# Altium schematic sheet Launchpad.~(23).SchDoc(Launchpad.~(23))
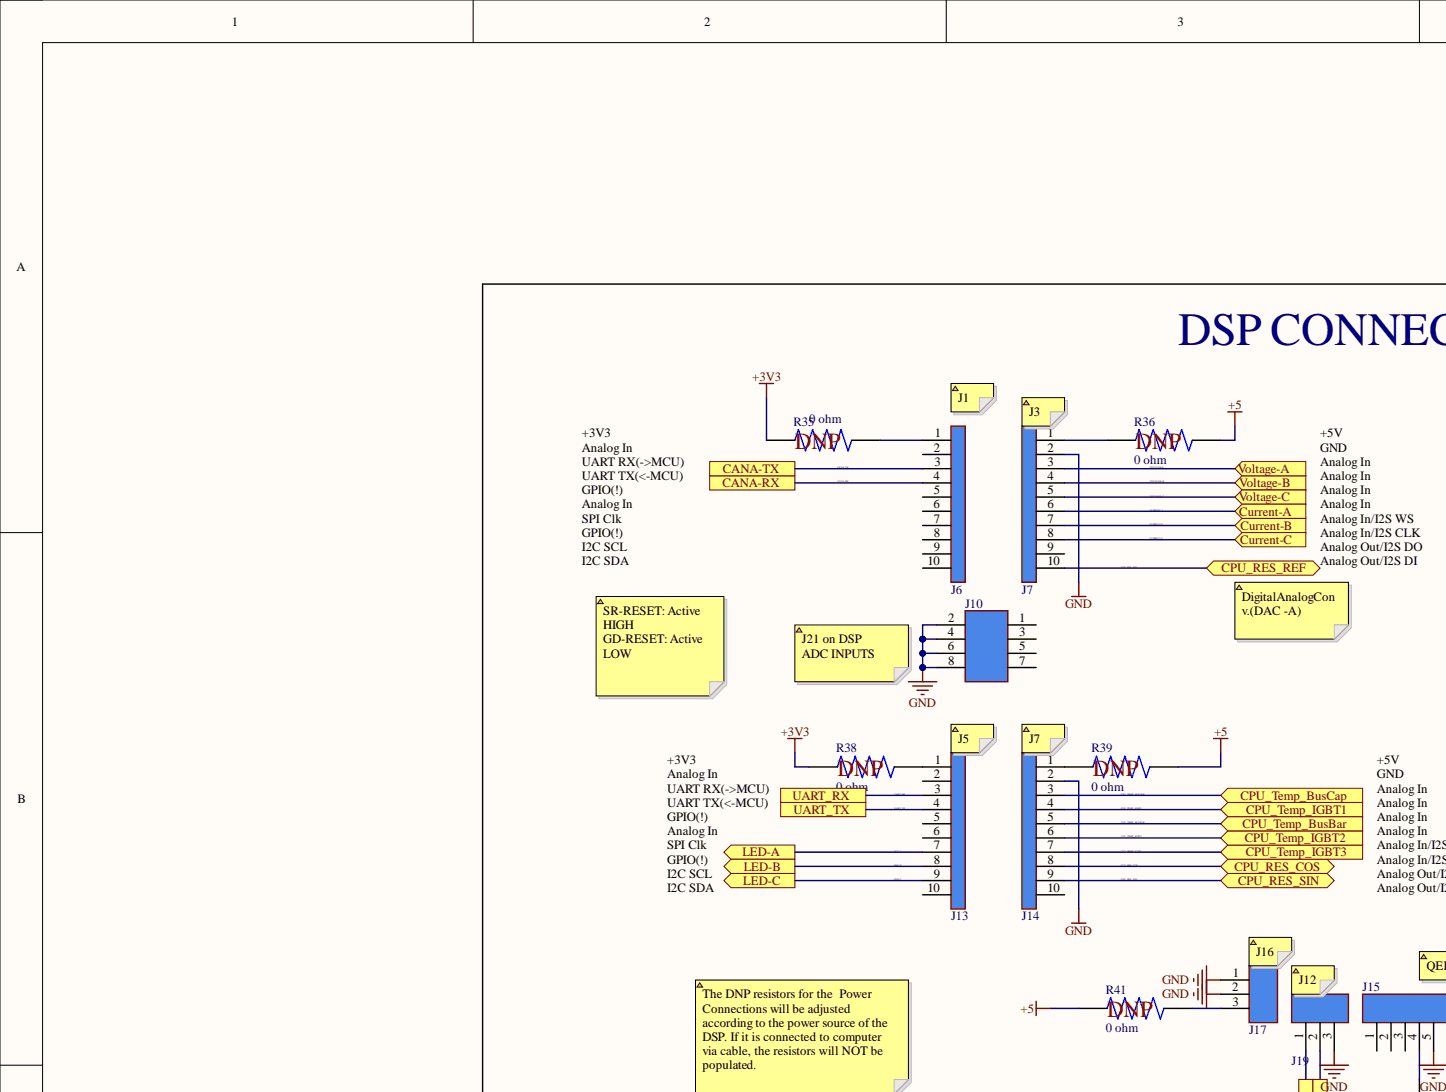
[diagram p0: Launchpad.~, top left]
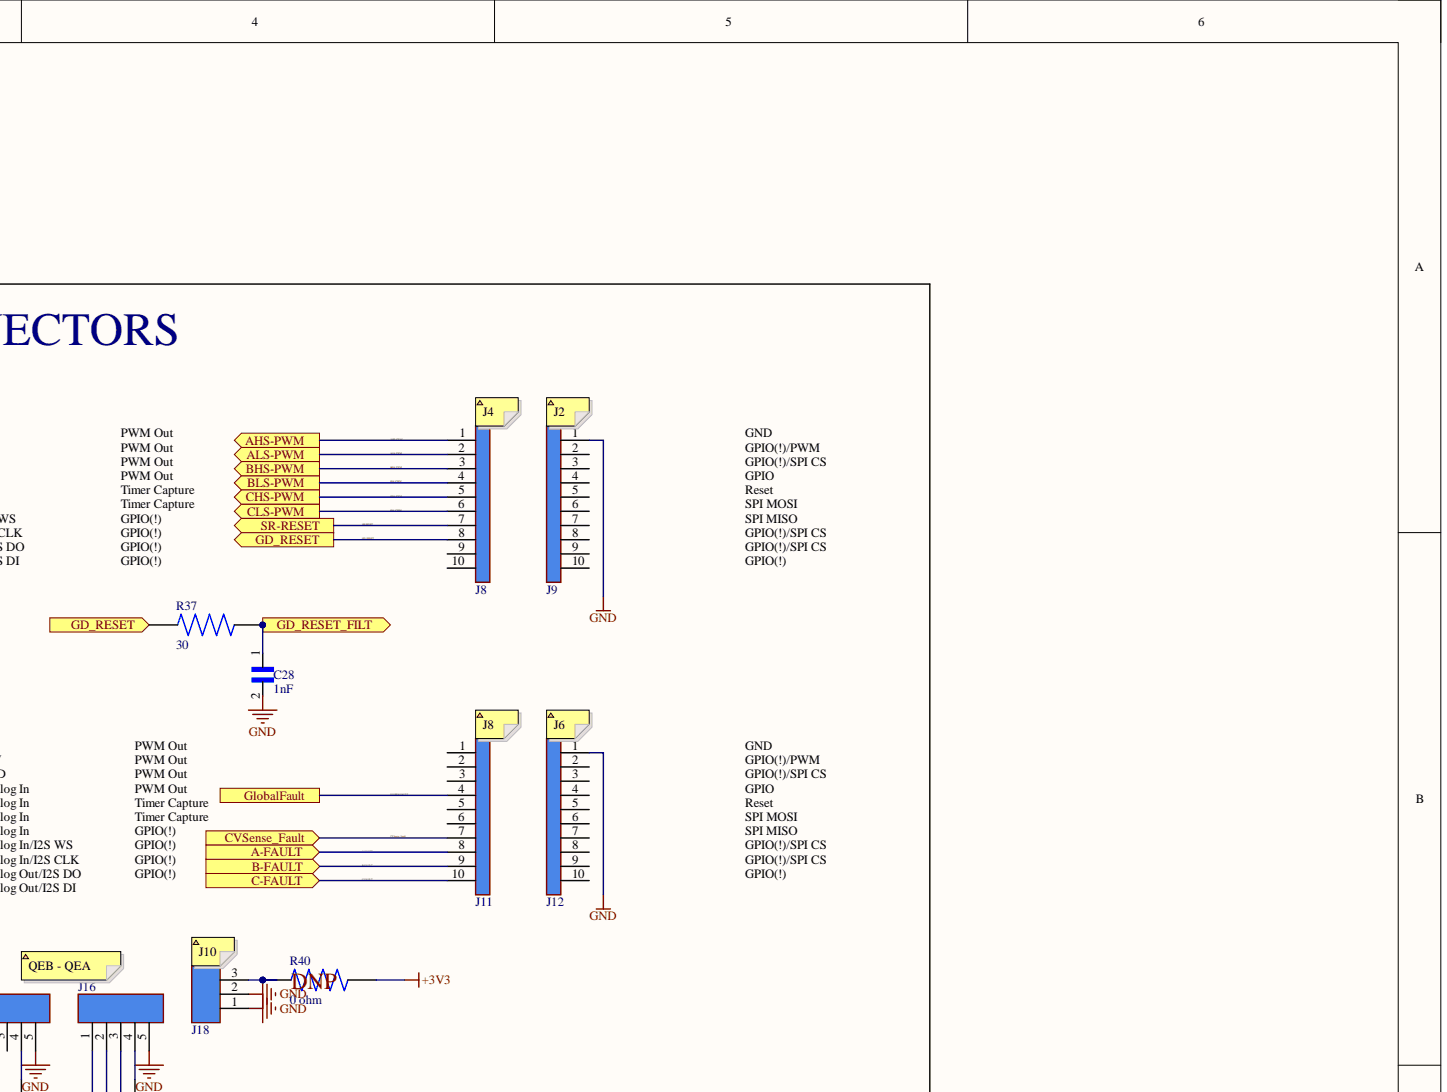
[diagram p1: Launchpad.~, top right]
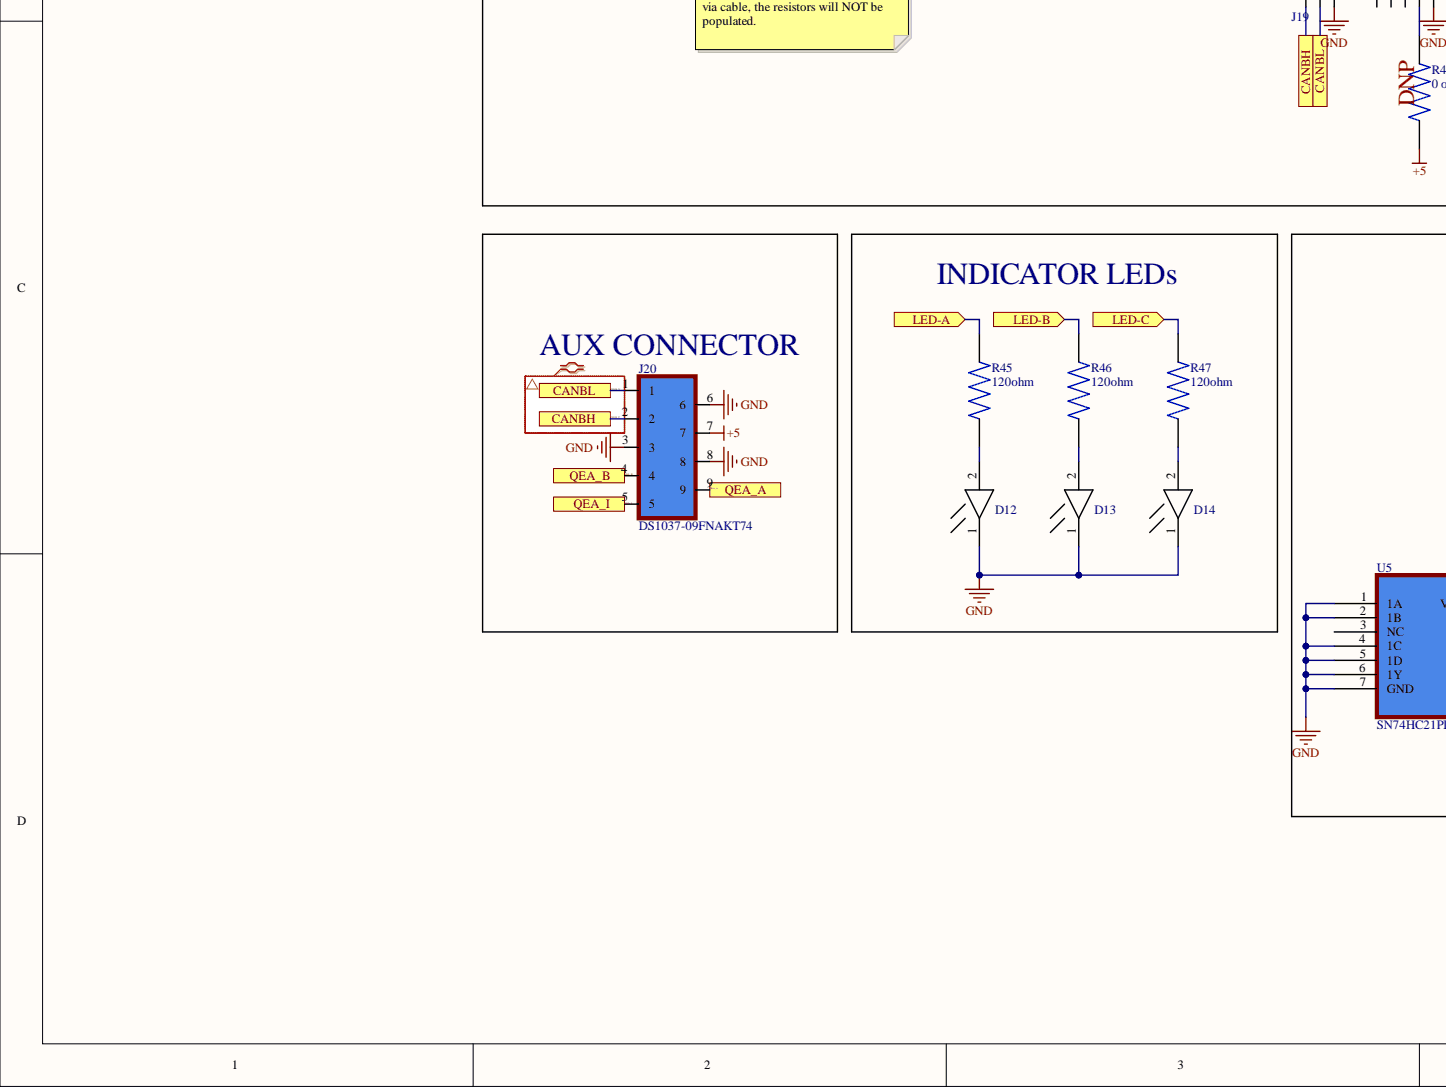
[diagram p2: Launchpad.~, bottom left]
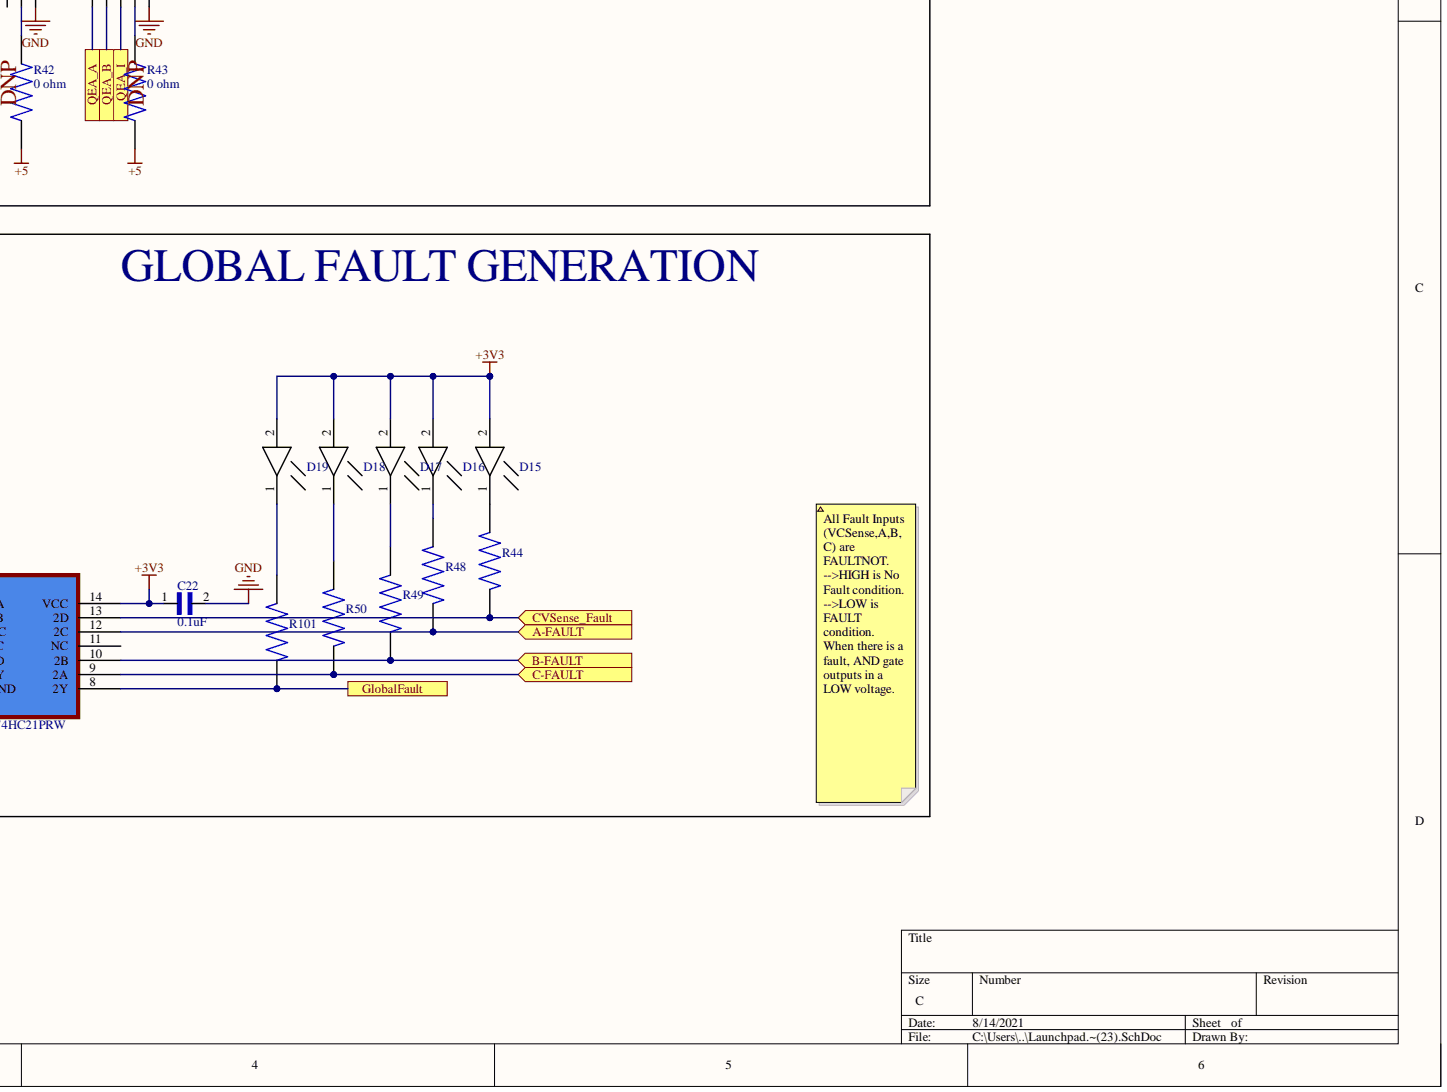
[diagram p3: Launchpad.~, bottom right]

## Parts
C22  0.1uF  [CAP 0805(2012 metric)]
C28  1nF  [CAP 0805(2012 metric)]
D12  LED 17-21/Y2C-AN1P2/3T  [17-21/Y2C-AN1P2/3T]  (value not drawn)
D13  LED 17-21/Y2C-AN1P2/3T  [17-21/Y2C-AN1P2/3T]  (value not drawn)
D14  LED 17-21/Y2C-AN1P2/3T  [17-21/Y2C-AN1P2/3T]  (value not drawn)
D15  LED 17-21/Y2C-AN1P2/3T  [17-21/Y2C-AN1P2/3T]  (value not drawn)
D16  LED 17-21/Y2C-AN1P2/3T  [17-21/Y2C-AN1P2/3T]  (value not drawn)
D17  LED 17-21/Y2C-AN1P2/3T  [17-21/Y2C-AN1P2/3T]  (value not drawn)
D18  LED 17-21/Y2C-AN1P2/3T  [17-21/Y2C-AN1P2/3T]  (value not drawn)
D19  LED 17-21/Y2C-AN1P2/3T  [17-21/Y2C-AN1P2/3T]  (value not drawn)
J6  1ROW10POS  [1ROW10POS]  (value not drawn)
J7  1ROW10POS  [1ROW10POS]  (value not drawn)
J8  1ROW10POS  [1ROW10POS]  (value not drawn)
J9  1ROW10POS  [1ROW10POS]  (value not drawn)
J10  2ROW8POS  [2ROW8POS]  (value not drawn)
J11  1ROW10POS  [1ROW10POS]  (value not drawn)
J12  1ROW10POS  [1ROW10POS]  (value not drawn)
J13  1ROW10POS  [1ROW10POS]  (value not drawn)
J14  1ROW10POS  [1ROW10POS]  (value not drawn)
J15  1ROW5POS  [1ROW5POS]  (value not drawn)
J16  1ROW5POS  [1ROW5POS]  (value not drawn)
J17  1ROW3POS  [1ROW3POS]  (value not drawn)
J18  1ROW3POS  [1ROW3POS]  (value not drawn)
J19  1ROW3POS  [1ROW3POS]  (value not drawn)
J20  DS1037-09FNAKT74  [DSUB CONN 9 POS]
R35  0 ohm  [RES 0805(2012 metric)]
R36  0 ohm  [RES 0805(2012 metric)]
R37  30  [RES 0805(2012 metric)]
R38  0 ohm  [RES 0805(2012 metric)]
R39  0 ohm  [RES 0805(2012 metric)]
R40  0 ohm  [RES 0805(2012 metric)]
R41  0 ohm  [RES 0805(2012 metric)]
R42  0 ohm  [RES 0805(2012 metric)]
R43  0 ohm  [RES 0805(2012 metric)]
R44  1.24k  [RES 0805(2012 metric)]  (value not drawn)
R45  120ohm  [RES 0805(2012 metric)]
R46  120ohm  [RES 0805(2012 metric)]
R47  120ohm  [RES 0805(2012 metric)]
R48  1.24k  [RES 0805(2012 metric)]  (value not drawn)
R49  1.24k  [RES 0805(2012 metric)]  (value not drawn)
R50  1.24k  [RES 0805(2012 metric)]  (value not drawn)
R101  1.24k  [RES 0805(2012 metric)]  (value not drawn)
U5  SN74HC21PRW  [SN74HC21PWR]

## Ports
A-FAULT, AHS-PWM, ALS-PWM, B-FAULT, BHS-PWM, BLS-PWM, C-FAULT, CANA-RX, CANA-TX, CANBH_P, CANBL_N, CHS-PWM, CLS-PWM, CPU_RES_COS, CPU_RES_REF, CPU_RES_SIN, CPU_Temp_BusBar, CPU_Temp_BusCap, CPU_Temp_IGBT1, CPU_Temp_IGBT2, CPU_Temp_IGBT3, Current-A, Current-B, Current-C, CVSense_Fault, GD_RESET, GD_RESET_FILT, GlobalFault, LED-A, LED-B, LED-C, QEA_A, QEA_B, QEA_I, SR-RESET, UART_RX, UART_TX, Voltage-A, Voltage-B, Voltage-C

## Nets
+3V3: C22.1 D15.2 D16.2 D17.2 D18.2 D19.2 R35.1 R38.1 R40.1 U5.14(VCC)
+5: J20.7 R36.2 R39.2 R41.1 R42.1 R43.1
GND: C22.2 C28.2 D12.1 D13.1 D14.1 J7.2 J9.1 J10.2 J10.4 J10.6 J10.8 J12.1 J14.2 J15.5 J16.5 J17.1 J17.2 J18.1 J18.2 J19.3 J20.3 J20.6 J20.8 U5.1(1A) U5.2(1B) U5.4(1C) U5.5(1D) U5.6(1Y) U5.7(GND)
A-FAULT: J11.8 R48.1 U5.12(2C) ; port A-FAULT
AHS-PWM: J8.1 ; port AHS-PWM
ALS-PWM: J8.2 ; port ALS-PWM
B-FAULT: J11.9 R49.1 U5.10(2B) ; port B-FAULT
BHS-PWM: J8.3 ; port BHS-PWM
BLS-PWM: J8.4 ; port BLS-PWM
C-FAULT: J11.10 R50.1 U5.9(2A) ; port C-FAULT
CANA-RX: J6.4 ; port CANA-RX
CANA-TX: J6.3 ; port CANA-TX
CANB_N: J19.2 J20.1
CANB_P: J19.1 J20.2
CHS-PWM: J8.5 ; port CHS-PWM
CLS-PWM: J8.6 ; port CLS-PWM
CPU_RES_COS: J14.8 ; port CPU_RES_COS
CPU_RES_REF: J7.10 ; port CPU_RES_REF
CPU_RES_SIN: J14.9 ; port CPU_RES_SIN
CPU_TEMP_BUSBAR: J14.5 ; port CPU_Temp_BusBar
CPU_TEMP_BUSCAP: J14.3 ; port CPU_Temp_BusCap
CPU_TEMP_IGBT1: J14.4 ; port CPU_Temp_IGBT1
CPU_TEMP_IGBT2: J14.6 ; port CPU_Temp_IGBT2
CPU_TEMP_IGBT3: J14.7 ; port CPU_Temp_IGBT3
CURRENT-A: J7.6 ; port Current-A
CURRENT-B: J7.7 ; port Current-B
CURRENT-C: J7.8 ; port Current-C
CVSense_Fault: J11.7 R44.1 U5.13(2D) ; port CVSense_Fault
GD-RESET: J8.8 R37.1 ; port GD_RESET
GD_RESET_FILT: C28.1 R37.2 ; port GD_RESET_FILT
GLOBALFAULT: J11.4 R101.1 U5.8(2Y) ; port GlobalFault
LED-A: J13.7 R45.2 ; port LED-A
LED-B: J13.8 R46.2 ; port LED-B
LED-C: J13.9 R47.2 ; port LED-C
QEA_A: J16.1 J20.9 ; port QEA_A
QEA_B: J16.2 J20.4 ; port QEA_B
QEA_I: J16.3 J20.5 ; port QEA_I
SR-RESET: J8.7 ; port SR-RESET
UART_RX: J13.3 ; port UART_RX
UART_TX: J13.4 ; port UART_TX
VOLTAGE-A: J7.3 ; port Voltage-A
VOLTAGE-B: J7.4 ; port Voltage-B
VOLTAGE-C: J7.5 ; port Voltage-C
NetD12_2: D12.2 R45.1
NetD13_2: D13.2 R46.1
NetD14_2: D14.2 R47.1
NetD15_1: D15.1 R44.2
NetD16_1: D16.1 R48.2
NetD17_1: D17.1 R49.2
NetD18_1: D18.1 R50.2
NetD19_1: D19.1 R101.2
NetJ6_1: J6.1 R35.2
NetJ7_1: J7.1 R36.1
NetJ13_1: J13.1 R38.2
NetJ14_1: J14.1 R39.1
NetJ15_4: J15.4 R42.2
NetJ16_4: J16.4 R43.2
NetJ17_3: J17.3 R41.2
NetJ18_3: J18.3 R40.2

Not connected: J6 2 5 6 7 8 9 10; J7 9; J8 9 10; J9 2 3 4 5 6 7 8 9 10; J10 1 3 5 7; J11 1 2 3 5 6; J12 2 3 4 5 6 7 8 9 10; J13 2 5 6 10; J14 10; J15 1 2 3; U5 3(NC) 11(NC)

## Library data of the parts
C22 = 0.1uF: 0.1µF ±10% 25V Ceramic Capacitor X7R 0805; Component Kind: Standard; Library Name: 0.1uF 25 V 0805.SchLib
C28 = 0.1uF 25V 0805: 0.1µF ±10% 25V Ceramic Capacitor X7R 0805 (2012Metric); Component Kind: Standard; Library Name: 0.1uF 25V 0805.SchLib
D12-D19 = LED: Component Kind: Standard; Library Name: LED 17-21 Y2C-AN1P2 3T.SchLib
J20 = DSBU CONN 9 POS: Component Kind: Standard; Description: DS1037-09FNAKT74; Library Name: DSUB CONN 9 POS.SchLib
R35-R43 = 0ohm 0805: 0 ohm 0805 res; Component Kind: Standard; Library Name: 0ohm 0805.SchLib
R44 R48-R50 R101 = 1.24k 0805: Component Kind: Standard; Description: 1.24k 0805; Library Name: 1.24k 0805.SchLib
R45-R47 = 120ohm 0805: 120 Ohms ±5% 0.1W, 1/10W Chip Resistor 0805 (2012 Metric) Moisture Resistant Thick Film; Component Kind: Standard; Library Name: 120ohm 0805.SchLib
J6-J9 J11-J14 = 1ROW10POS: Component Kind: Standard; Library Name: 1ROW10POS.SchLib
J10 = 2ROW8POS: Component Kind: Standard; Library Name: 2ROW8POS.SchLib
J15 J16 = 1ROW5POS: Component Kind: Standard; Library Name: 1ROW5POS.SchLib
J17-J19 = 1ROW3POS: Component Kind: Standard; Library Name: 1ROW3POS.SchLib
U5 = SN74HC21PRW: Component Kind: Standard; Library Name: SN74HC21PRW.SchLib
